# Altium schematic sheet CANTRICK PERIPHERAL.SchDoc("U_CANTRICK PERIPHERAL")
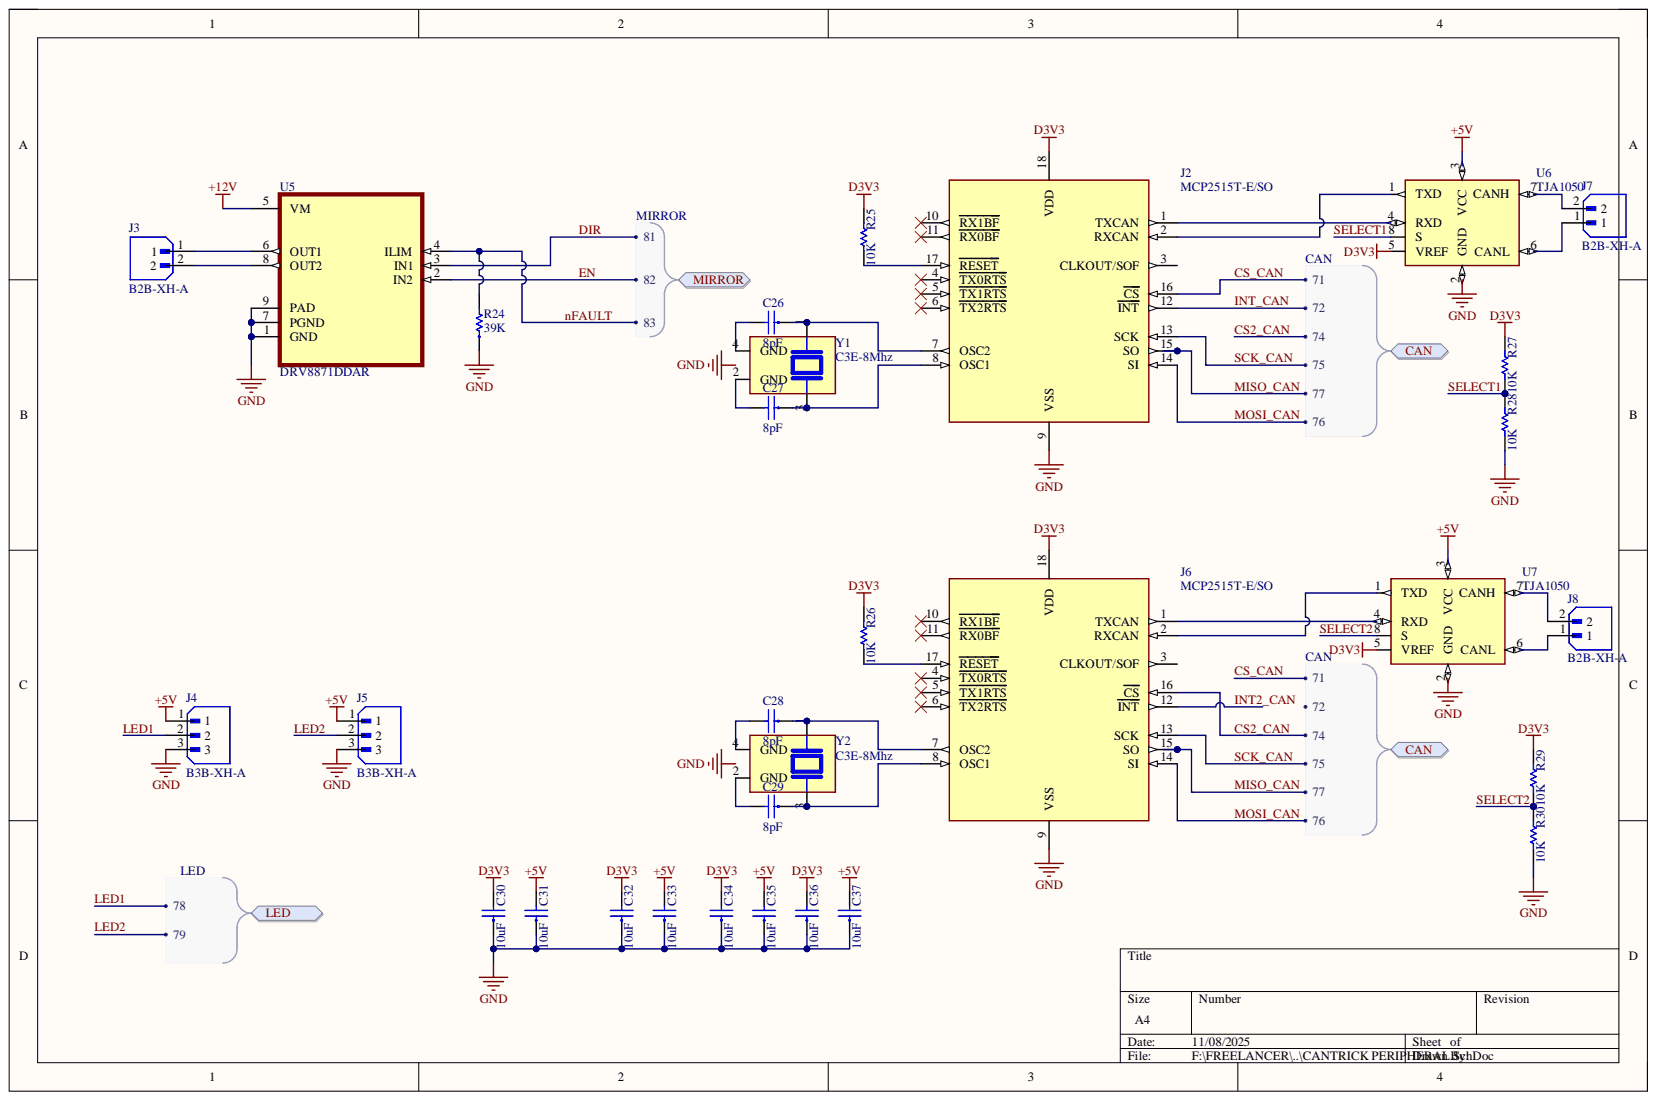
[diagram p0: CANTRICK PERIPHERAL.SchDoc]

## Parts
C26  8pF  [0402]
C27  8pF  [0402]
C28  8pF  [0402]
C29  8pF  [0402]
C30  10uF  [0603]
C31  10uF  [0603]
C32  10uF  [0603]
C33  10uF  [0603]
C34  10uF  [0603]
C35  10uF  [0603]
C36  10uF  [0603]
C37  10uF  [0603]
J2  MCP2515T-E/SO  [SOIC127P1030X265-18N]
J3  B2B-XH-A  [JST_B2B-XH-A]
J4  B3B-XH-A  [JST_B3B-XH-A]
J5  B3B-XH-A  [JST_B3B-XH-A]
J6  MCP2515T-E/SO  [SOIC127P1030X265-18N]
J7  B2B-XH-A  [JST_B2B-XH-A]
J8  B2B-XH-A  [JST_B2B-XH-A]
R24  39K  [RES-CHP0402-0.35H]
R25  10K  [Res 0603]
R26  10K  [Res 0603]
R27  10K  [Res 0603]
R28  10K  [Res 0603]
R29  10K  [Res 0603]
R30  10K  [Res 0603]
U5  DRV8871DDAR  [VREG_LM5017MR/NOPB]
U6  TJA1050  [SOT96-1]
U7  TJA1050  [SOT96-1]
Y1  C3E-8Mhz  [XTAL_C3E-8.000-8-1015-R]
Y2  C3E-8Mhz  [XTAL_C3E-8.000-8-1015-R]

## Ports
CAN, CAN.71, CAN.72, CAN.74, CAN.75, CAN.76, CAN.77, LED, LED.78, LED.79, MIRROR, MIRROR.81, MIRROR.82, MIRROR.83

## Nets
+5V: C31.2 C33.2 C35.2 C37.2 J4.1 J5.1 U6.3(VCC) U7.3(VCC)
+12V: U5.5(VM)
D3V3: C30.2 C32.2 C34.2 C36.2 J2.18(VDD) J6.18(VDD) R25.2 R26.2 R27.2 R29.2 U6.5(VREF) U7.5(VREF)
GND: C30.1 C31.1 C32.1 C33.1 C34.1 C35.1 C36.1 C37.1 J2.9(VSS) J4.3 J5.3 J6.9(VSS) R24.1 R28.1 R30.1 U5.1(GND) U5.7(PGND) U5.9(PAD) U6.2(GND) U7.2(GND)
CS2_CAN: J6.16(CS) ; port CAN
CS_CAN: J2.16(CS) ; port CAN
DIR: U5.3(IN1) ; port MIRROR
EN: U5.2(IN2) ; port MIRROR
INT2_CAN: J6.12(INT)
INT_CAN: J2.12(INT) ; port CAN
LED1: J4.2 ; port LED
LED2: J5.2 ; port LED
MISO_CAN: J2.15(SO) J6.15(SO) ; port CAN
MOSI_CAN: J2.14(SI) J6.14(SI) ; port CAN
nFAULT: R24.2 U5.4(ILIM) ; port MIRROR
SCK_CAN: J2.13(SCK) J6.13(SCK) ; port CAN
SELECT1: R27.1 R28.2 U6.8(S)
SELECT2: R29.1 R30.2 U7.8(S)
NetC26_1: C26.1 J2.7(OSC2) Y1.1
NetC26_2: C26.2 Y1.4(GND)
NetC27_1: C27.1 J2.8(OSC1) Y1.3
NetC27_2: C27.2 Y1.2(GND)
NetC28_1: C28.1 J6.7(OSC2) Y2.1
NetC28_2: C28.2 Y2.4(GND)
NetC29_1: C29.1 J6.8(OSC1) Y2.3
NetC29_2: C29.2 Y2.2(GND)
NetJ2_1: J2.1(TXCAN) U6.4(RXD)
NetJ2_2: J2.2(RXCAN) U6.1(TXD)
NetJ2_17: J2.17(RESET) R25.1
NetJ3_1: J3.1 U5.6(OUT1)
NetJ3_2: J3.2 U5.8(OUT2)
NetJ6_1: J6.1(TXCAN) U7.4(RXD)
NetJ6_2: J6.2(RXCAN) U7.1(TXD)
NetJ6_17: J6.17(RESET) R26.1
NetJ7_1: J7.1 U6.6(CANL)
NetJ7_2: J7.2 U6.7(CANH)
NetJ8_1: J8.1 U7.6(CANL)
NetJ8_2: J8.2 U7.7(CANH)

Not connected: J2 3(CLKOUT/SOF) 4(TX0RTS) 5(TX1RTS) 6(TX2RTS) 10(RX1BF) 11(RX0BF); J6 3(CLKOUT/SOF) 4(TX0RTS) 5(TX1RTS) 6(TX2RTS) 10(RX1BF) 11(RX0BF)

## Library data of the parts
C26-C29 = CAPCER0013 100nF 10% 50V X7R 0402 SMD: CAP CER 100nF 10% 50V X7R 0402 SMD; CERTIFICATION: NA; CLASS: B; LINE: SMT; TMG CODE: None; TYPE: EP; UNIT: PCS; Component Kind: Standard; ComponentLink1Description: Datasheet; ComponentLink1URL: https://datasheet.lcsc.com/lcsc/1811021431_Samsung-Electro-Mechanics-CL10B104KB8NNNC_C1591.pdf; MOUNT: SMD; TMG PN: CAPCER0013; Library Name: 2502-GMQLITE-MAIN.SCHLIB
C30-C37 = CAPCER0001 100nF 10% 50V X7R 0603 SMD: CAP CER 100nF 10% 50V X7R 0603 SMD; CERTIFICATION: NA; CLASS: B; LINE: SMT; TMG CODE: None; TYPE: EP; UNIT: PCS; Component Kind: Standard; ComponentLink1Description: Datasheet; ComponentLink1URL: https://datasheet.lcsc.com/lcsc/1811021431_Samsung-Electro-Mechanics-CL10B104KB8NNNC_C1591.pdf; MOUNT: SMD; TMG PN: CAPCER0001; Library Name: NAVIGATION.SCHLIB
J2 J6 = MCP2515T-E/SO: CAN controller w/ SPI interface, 125 deg C18 SOIC .300in T/R | Microchip Technology Inc. MCP2515T-E/SO; Check_prices: https://www.snapeda.com/parts/MCP2515T-E/SO/Microchip/view-part/?ref=eda; Component Kind: Standard; Library Name: MCP2515T-E_SO.SchLib; MF: Microchip; MP: MCP2515T-E/SO; Package: SOIC-18 Microchip; SnapEDA_Link: https://www.snapeda.com/parts/MCP2515T-E/SO/Microchip/view-part/?ref=snap
J3 J7 J8 = B2B-XH-A: MF: {'manufacturer': u'JST Sales America Inc.'}; Availability: Unavailable; Component Kind: Standard; Library Name: B2B-XH-A.IntLib; MP: B2B-XH-A; Package: None; Price: None
J4 J5 = B3B-XH-A: Connector Header Through Hole 3 position 0.098 (2.50mm); MF: JST Sales; Check_prices: https://www.snapeda.com/parts/B3B-XH-A/JST+Sales+America+Inc./view-part/?ref=eda; Component Kind: Standard; Library Name: B3B-XH-A.IntLib; MP: B3B-XH-A; Package: None; SnapEDA_Link: https://www.snapeda.com/parts/B3B-XH-A/JST+Sales+America+Inc./view-part/?ref=snap
R24 = RESCHP0087 39K 1% 0402 SMD: RES CHP 39K 1% 1/16W 0402 SMD; CERTIFICATION: NA; CLASS: B; LINE: SMT; TMG CODE: None; UNIT: PCS; Component Kind: Standard; ComponentLink1Description: Datasheet; ComponentLink1URL: https://datasheet.lcsc.com/lcsc/2304140030_YAGEO-AC0402FR-0739KL_C144763.pdf; MOUNT: SMD; TYPE: EP; Library Name: 2502-GMQLITE-MAIN.SCHLIB
R25-R30 = Resistor 2.2K: Res Thick Film 0603 2.2K Ohm 5% 1/10W ±200ppm/°C Molded SMD SMD Paper T/R; Manufacturer: Vishay; Manufacturer Part Number: CRCW06032K20JNEA; Max Power Dissipation: 100mW; Resistance: 2.2kR; Voltage Rating: 75V; Case Code (Imperial): 0603; Case Code (Metric): 1608; Case/Package: 0603; Category: Chip SMD Resistors; Component Kind: Standard; ComponentLink1Description: https://datasheet.ciiva.com/2462/dcrcwe3-2462048.pdf?src-supplier=Digikey; ComponentLink1URL: https://datasheet.ciiva.com/2462/dcrcwe3-2462048.pdf?src-supplier=Digikey; ComponentLink2Description: https://datasheet.ciiva.com/16767/70201119-16767464.pdf?src-supplier=Allied+Electronics; ComponentLink2URL: https://datasheet.ciiva.com/16767/70201119-16767464.pdf?src-supplier=Allied+Electronics; ComponentLink3Description: https://datasheet.ciiva.com/2462/dcrcwe3-2462046.pdf?src-supplier=Digikey; ComponentLink3URL: https://datasheet.ciiva.com/2462/dcrcwe3-2462046.pdf?src-supplier=Digikey; ComponentLink4Description: https://datasheet.ciiva.com/5541/dcrcwe3-109170-5541959.pdf?src-supplier=Mouser; ComponentLink4URL: https://datasheet.ciiva.com/5541/dcrcwe3-109170-5541959.pdf?src-supplier=Mouser; ComponentLink5Description: https://datasheet.ciiva.com/16286/dcrcwe3-16286997.pdf?src-supplier=Future+Electronics; ComponentLink5URL: https://datasheet.ciiva.com/16286/dcrcwe3-16286997.pdf?src-supplier=Future+Electronics; Construction: Rectangular; Contact Plating: Tin; Depth: 0.85mm; Features: Automotive AEC-Q200; Height: 0.45mm; Lead Free: 5697LeadFree; Length: 1.55mm; Library Name: Stm32_Flyback_Driver_250814_1.SCHLIB; Max Operating Temperature: 155°C; Military Standard: Not; Min Operating Temperature: -55°C; Number of Terminations: 2; Package Quantity: 5000; Packaging: Tape and Reel; Power Rating: 100mW; Pricing 1: 5000=0.0053 (USD); Radiation Hardening: No; Ratings: AEC-Q200; Reach SVHC Compliant: Unknown; Resistance Tolerance: 5%; Resistor Type: Thick Film; RoHS: Yes; RoHS Compliant: Yes; Series: CRCW; Size Code: 0603; Stock 1: 130000; Supplier 1: Arrow Electronics; Supplier Part Number 1: CRCW06032K20JNEA; Temperature Coefficient: 200ppm/°C; Termination: SMD/SMT; Tolerance: 5%; Weight: 7.1E-05oz; Width: 0.85mm
U5 = DRV8871DDAR: 50-V, 3.6-A H-bridge motor driver with integrated current sensing; MF: Texas Instruments; Check_prices: https://www.snapeda.com/parts/DRV8871DDAR/Texas+Instruments/view-part/?ref=eda; Component Kind: Standard; Library Name: DRV8871DDAR.SchLib; MP: DRV8871DDAR; Package: HSOIC-8 Texas Instruments; SnapEDA_Link: https://www.snapeda.com/parts/DRV8871DDAR/Texas+Instruments/view-part/?ref=snap
U6 U7 = TJA1050: CAN 1MBd Silent 5V Automotive 8-Pin SO T/R; MF: NXP Semiconductors; Check_prices: https://www.snapeda.com/parts/TJA1050/NXP+Semiconductors/view-part/?ref=eda; Component Kind: Standard; Library Name: TJA1050.IntLib; MP: TJA1050; Package: None; SnapEDA_Link: https://www.snapeda.com/parts/TJA1050/NXP+Semiconductors/view-part/?ref=snap
Y1 Y2 = C3E-8.000-8-1015-R: Availability: Unavailable; Component Kind: Standard; Library Name: C3E-8.000-8-1015-R.SchLib; MP: C3E-8.000-8-1015-R; Package: None; Price: None
